# Altium schematic sheet main.SchDoc
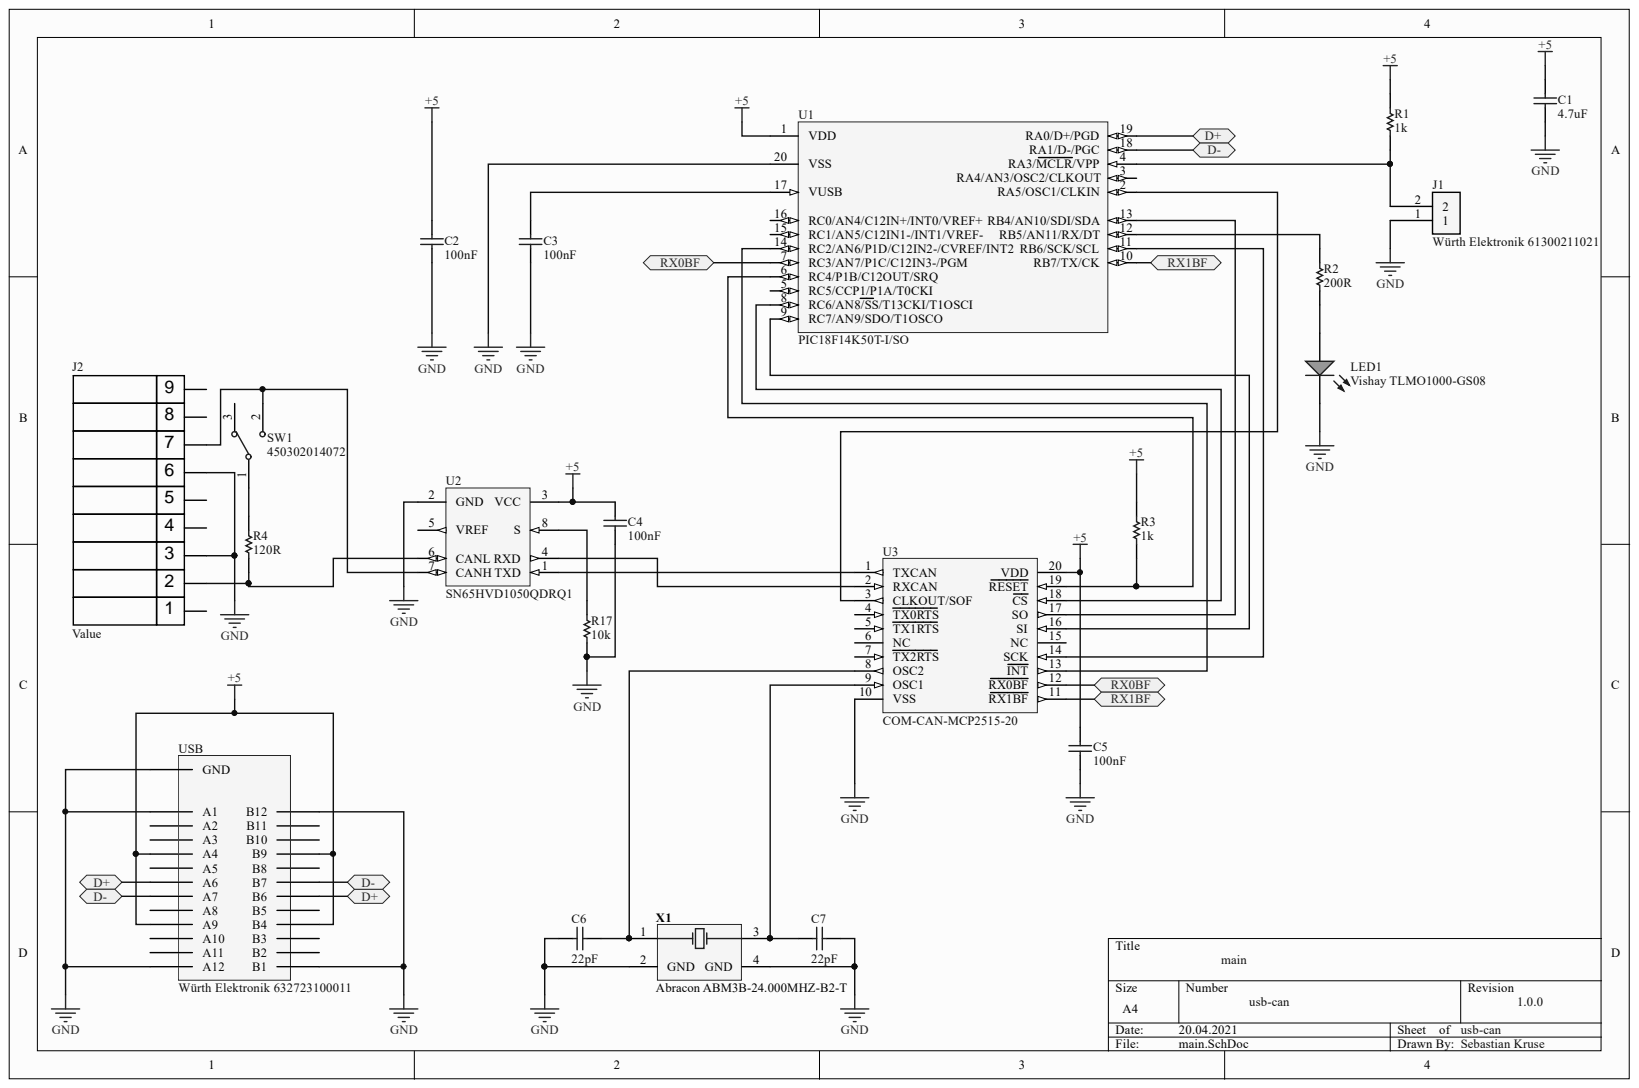
[diagram p0: main.SchDoc]

## Parts
C1  4.7uF  [PCB-0wz5cygkzjk26dn1bz6x-1]
C2  100nF  [PCB-123646-1]
C3  100nF  [PCB-123646-1]
C4  100nF  [PCB-123646-1]
C5  100nF  [PCB-123646-1]
C6  22pF  [CAPC1508X91X25ML25T13]
C7  22pF  [CAPC1508X91X25ML25T13]
J1  Würth Elektronik 61300211021  [PCB-t2j72m1yraok0w090vy8-1]
J2  Value  [PCB-06li7dsinirebf53x9du-1]
LED1  Vishay TLMO1000-GS08  [0603D_B]
R1  1k  [PCBComponent_1]
R2  200R  [RESC1608X55X30LL15T15]
R3  1k  [PCBComponent_1]
R4  120R  [RESC1608X06M]
R17  10k  [RESC1608X55X30NL20T20]
SW1  450302014072  [450302014072]
U1  PIC18F14K50T-I/SO  [SOIC-SO20_N]  (value not drawn)
U2  SN65HVD1050QDRQ1  [D8_M]
U3  COM-CAN-MCP2515-20  [TSSOP-ST20_L]
USB  Würth Elektronik 632723100011  Manufacturer Part Number: 632723100011  [PCB-ed55rmwyicl0n6piu1yt-1]
X1  Abracon ABM3B-24.000MHZ-B2-T  Manufacturer Part Number: ABM3B-24.000MHZ-B2-T  [ABRA-ABM3B-4_V]

## Ports
D+, D-, RX0BF, RX1BF

## Nets
+5: C1.2 C2.2 C4.2 C5.2 R1.2 R3.2 U1.1(VDD) U2.3(VCC) U3.20(VDD) USB.3(A4) USB.8(A9) USB.15(B4) USB.20(B9)
GND: C1.1 C2.1 C3.1 C4.1 C5.1 C6.1 C7.2 J1.1 J2.3 J2.6 LED1.2 R17.2 U1.20(VSS) U2.2(GND) U3.10(VSS) USB.0(A1) USB.11(A12) USB.12(B1) USB.23(B12) USB.24(GND) X1.2(GND) X1.4(GND)
RX0BF: U1.7(RC3/AN7/P1C/C12IN3-/PGM) U3.12(RX0BF) ; port RX0BF
RX1BF: U1.10(RB7/TX/CK) U3.11(RX1BF) ; port RX1BF
NetC3_2: C3.2 U1.17(VUSB)
NetC6_2: C6.2 U3.8(OSC2) X1.1
NetC7_1: C7.1 U3.9(OSC1) X1.3
NetJ1_2: J1.2 R1.1 U1.4(RA3/MCLR/VPP)
NetJ2_2: J2.2 R4.1 U2.6(CANL)
NetJ2_7: J2.7 SW1.2 U2.7(CANH)
NetLED1_1: LED1.1 R2.1
NetR2_2: R2.2 U1.12(RB5/AN11/RX/DT)
NetR3_1: R3.1 U1.6(RC4/P1B/C12OUT/SRQ) U3.19(RESET)
NetR4_2: R4.2 SW1.1
NetR17_1: R17.1 U2.8(S)
NetU1_2: U1.2(RA5/OSC1/CLKIN) U3.3(CLKOUT/SOF)
NetU1_8: U1.8(RC6/AN8/SS/T13CKI/T1OSCI) U3.18(CS)
NetU1_9: U1.9(RC7/AN9/SDO/T1OSCO) U3.16(SI)
NetU1_11: U1.11(RB6/SCK/SCL) U3.14(SCK)
NetU1_13: U1.13(RB4/AN10/SDI/SDA) U3.17(SO)
NetU1_14: U1.14(RC2/AN6/P1D/C12IN2-/CVREF/INT2) U3.13(INT)
NetU1_18: U1.18(RA1/D-/PGC) USB.6(A7) USB.18(B7)
NetU1_19: U1.19(RA0/D+/PGD) USB.5(A6) USB.17(B6)
NetU2_1: U2.1(TXD) U3.1(TXCAN)
NetU2_4: U2.4(RXD) U3.2(RXCAN)

Not connected: J2 1 4 5 8 9; SW1 3; U1 3(RA4/AN3/OSC2/CLKOUT) 5(RC5/CCP1/P1A/T0CKI) 15(RC1/AN5/C12IN1-/INT1/VREF-) 16(RC0/AN4/C12IN+/INT0/VREF+); U2 5(VREF); U3 4(TX0RTS) 5(TX1RTS) 6(NC) 7(TX2RTS) 15(NC); USB 1(A2) 2(A3) 4(A5) 7(A8) 9(A10) 10(A11) 13(B2) 14(B3) 16(B5) 19(B8) 21(B10) 22(B11)

## Library data of the parts
C1 = CMP-b2777a530dcc6db0-3: CAP, 4.7UF, 16V, MLCC, 0603; SVHC: No SVHC (15-Jan-2019) RoHS Compliant: Yes; Capacitance: 4.70 µF; Capacitance Tolerance: ±10%; Case/Package: 0603; Component Kind: Standard; Library Name: CommunityVault; Mounting Style: Surface Mount; OctopartID: b2777a530dcc6db0
C2-C5 = CMP-123646-13: CAP CER 0.1UF 16V 10% X7R 0603; Component Kind: Standard; Library Name: CommunityVault
C6 C7 = CMP-174923-2: CAP CER 22PF 50V 2% NP0 0603; Manufacturer Part Number: 06035U220GAT2A; Capacitance: 2.2e-11 F; Lead-Free Status: Lead Free; Voltage Rating (DC): 50.0 V; Capacitance Tolerance: ±2%; Case/Package: 0603; Component Kind: Standard; Library Name: CommunityVault; OctopartID: 52ac9b2cfb468d6b; Octopart Url: https://octopart.com/06035u220gat2a-avx+interconnect+%2F+elco-9079948; Packaging: Tape; RoHS: Compliant
J1 = CMP-7939b1c60980a6fe-3: Board-To-Board Connector, Right Angle, WR-PHD Series, Through Hole, Header, 2, 2.54 mm; Gender: Male; Number of Contacts: 2; Component Kind: Standard; Contact Material: Copper; Contact Plating: Gold; Library Name: CommunityVault; Manufacturer Part Number: 61300211021; Mounting Style: Through Hole; OctopartID: 7939b1c60980a6fe; Octopart URL: http://octopart.com/61300211021-w%C3%BCrth+elektronik-32855519; REACH SVHC Compliance: No SVHC; RoHS: Compliant
J2 = CMP-bd0475527f2c62c3-1: D Sub Connector, Right Angle, R/a Pcb Mount D Sub, De, Plug, 9, Solder Rohs Compliant: Yes; Component Kind: Standard; Library Name: CommunityVault
LED1 = CMP-f5263eace1152ae1-2: Soft Orange 0603 160º 15 mA 1.8 V 7.5 mcd Surface Mount LED; Forward Voltage: 1.80 V; Lead-Free Status: Lead Free; Luminous Intensity: 7.50 mcd; Peak Wavelength: 610 nm; Power Dissipation: 40.0 mW; Viewing Angle: 80 °; Case/Package: 0603; Color: Orange; Component Kind: Standard; Library Name: CommunityVault; Manufacturer Part Number: TLMO1000-GS08; Mounting Style: Surface Mount; Number of Pins: 2; OctopartID: f5263eace1152ae1; Octopart Url: http://octopart.com/tlmo1000-gs08-vishay-41955669; Packaging: Cut Tape (CT); REACH SVHC Compliance: No SVHC; RoHS: Compliant; Seller Landing  Url: https://octopart-clicks.com/click/track?country=US&ak=8157f166&sig=09ba485&sid=2402&ppid=41955669&vpid=115422634&ct=offers; Wavelength: 610 nm
R1 R3 = CMP-a3f568390af67c32-1: Res Thin Film 0603 1K Ohm 1% 1/10W ±50ppm/°C Molded SMD SMD Paper T/R; Manufacturer Part Number: MCT06030C1001FP500; Resistance: 1.00 kΩ; Component Kind: Standard; Library Name: CommunityVault; Mounting Style: Surface Mount; Packaging: Cut Tape (CT); REACH SVHC Compliance: No SVHC; Resistance Tolerance: ±1%; RoHS: Compliant
R2 = CMP-3655549-2: Thick Film Resistors 0603 200ohms 5% Tol; Manufacturer Part Number: ERJ-3GEYJ201V; Lead-Free Status: Lead Free; Power Rating: 0.1 W; Resistance: 200.0 Ω; Voltage Rating (DC): 75.0 V; Case/Package: 0603; Component Kind: Standard; Library Name: CommunityVault; Lifecycle Status: Not Listed by Manufacturer; Mounting Style: Surface Mount; OctopartID: dd42170554680285; Octopart Url: https://octopart.com/erj-3geyj201v-panasonic-55422028; Packaging: Cut Tape (CT); REACH SVHC Compliance: No SVHC; Resistance Tolerance: ±5%; RoHS: Compliant; Size-Height: 0.00045 m; Size-Length: 0.0016 m; Size-Width: 0.0008 m
R4 = CMP-5a4693c156398581-2: Precision resistor, SMD [Panasonic Automotive & Industrial Systems] ERJ3EKF1200V Precision resistor, SMD; Case/Package (SI): 1608; Lead-Free Status: Lead Free; Resistance: 120 Ω; Resistance Tolerance: ±1%; Case/Package: 0603; Component Kind: Standard; Library Name: CommunityVault; Lifecycle Status: Not Listed by Manufacturer; Number of Pins: 2; OctopartID: 5a4693c156398581; REACH SVHC Compliance: No SVHC; RoHS: Compliant
R17 = CMP-3718261-2: Metal Film Resistors 10K   OHM  .1% 25PPM; Manufacturer Part Number: ERA-3YEB103V; Lead-Free Status: Lead Free; Power Rating: 0.063 W; Resistance: 10000.0 Ω; Resistance Tolerance: ±0.1%; Voltage Rating (DC): 75.0 V; Component Kind: Standard; Library Name: CommunityVault; Lifecycle Status: Not Listed by Manufacturer; Mounting Style: Surface Mount; OctopartID: b58c7fcbf3056a4b; Octopart Url: https://octopart.com/era-3yeb103v-panasonic-55403503; Packaging: Cut Tape (CT); RoHS: Compliant; Size-Height: 0.00045 m; Size-Length: 0.0016 m; Size-Width: 0.0008 m
SW1 = CMP-3800722-2: WS-SLTU 10mm*2.5mm, SPDT, ON-ON, 2.54mm, right angle type, THT, Mini Slide Switch, 3 pins; Contact Resistance: 0.03 Ω; Component Kind: Standard; Contacts Type: SPDT; Library Name: CommunityVault; Manufacturer Part Number: 450302014072; OctopartID: f055383458b82f92; Octopart Url: https://octopart.com/450302014072-w%C3%BCrth+elektronik-23825803; Orientation: Right Angle; REACH SVHC Compliance: No SVHC; RoHS: Compliant
U1 = CMP-1210952-2: USB Flash Microcontroller with nanoWatt XLP Technology, 20-pin SOIC, Industrial Temperature, Tape and Reel; Component Kind: Standard; Library Name: CommunityVault; Lifecycle Status: Active; Manufacturer Part Number: PIC18F14K50T-I/SO; OctopartID: 8e7a467c499151bd; Octopart Url: https://octopart.com/pic18f14k50t-i%2Fso-microchip-17621645; RoHS: Compliant
U2 = CMP-1226053-2: Automotive Catalog EMC Optimized CAN Transceiver, 70 mA, 5 V, -40 to 125 degC, 8-pin SOIC (D), Green (RoHS & no Sb/Br); Data Rate: 1000000.0 bps; Drivers Per Package: 1; Lead-Free Status: Lead Free; Case/Package: SOIC; Component Kind: Standard; Library Name: CommunityVault; Lifecycle Status: Active; Manufacturer Part Number: SN65HVD1050QDRQ1; Mounting Style: Surface Mount; Number of Pins: 8; OctopartID: aa3a6336008d75fd; Octopart Url: https://octopart.com/sn65hvd1050qdrq1-texas+instruments-430033; REACH SVHC Compliance: No SVHC; RoHS: Compliant
U3 = CMP-1158658-5: MCP2515 Series 5.5 V 1 Mb/s Stand Alone SPI Interface CAN Controller- TSSOP-20; Manufacturer Part Number: MCP2515T-I/ST; Supply Current: 10.0 mA; Supply Voltage (DC): 5.50 V (max), 2.70 V (min); Case/Package: TSSOP; Component Kind: Standard; Library Name: CommunityVault; Lifecycle Status: Active; Mounting Style: Surface Mount; Number of Pins: 20; OctopartID: 2c54e558995df393; Octopart URL: https://octopart.com/mcp2515t-i%2Fst-microchip-430191; Operating Temperature: -40.0 °C to 85.0 °C; RoHS: Compliant
USB = CMP-aaab67aedeef756c-4: USB 3.1 Type C Receptacle WR-COM, Horizontal, SMT / THT; Contact Resistance: 30.0 mΩ; Current Rating: 5.00 A; Operating Voltage: 20.0 V; Component Kind: Standard; Contact Material: Brass; Contact Plating: Gold; Library Name: CommunityVault; Mounting Style: Through Hole, Surface Mount; OctopartID: aaab67aedeef756c; Octopart URL: https://octopart.com/632723100011-w%C3%BCrth+elektronik-68112674; Operating Temperature: -40.0 °C (min); Orientation: Horizontal; Packaging: Tape & Reel (TR); RoHS: Compliant
X1 = CMP-780025-3: Ceramic SMD Crystal, 24 MHz, +/- 20 ppm, 50 Ohm, -20 to 70 degC, 4-Pin SMD, RoHS, Tape and Reel; Equivalent Series Resistance (ESR): 50.0 Ω; Frequency: 24.0 MHz; Lead-Free Status: Lead Free; Load Capacitance: 18.0 pF; Component Kind: Standard; DatasheetVersion: Aug-15; Library Name: CommunityVault; Mounting Style: Surface Mount; Number of Pins: 4; OctopartID: 37c2feccc227e805; Octopart Url: http://octopart.com/abm3b-24.000mhz-b2-t-abracon-315523; PackageDescription: 4-Pin Surface Mount Device, Body 5 x 3.2 mm; PackageReference: ABM3B; Packaging: Tape & Reel (TR), Cut Tape (CT); RoHS: Compliant; Seller Landing  Url: https://octopart-clicks.com/click/track?country=US&ak=8157f166&sig=030f7c4&sid=1106&ppid=315523&vpid=78496068&ct=offers; Size-Height: 1.10 mm; Size-Length: 5.00 mm; Size-Width: 3.20 mm
